# Altium schematic sheet STM32Bluetooth.SchDoc(STM32Bluetooth)
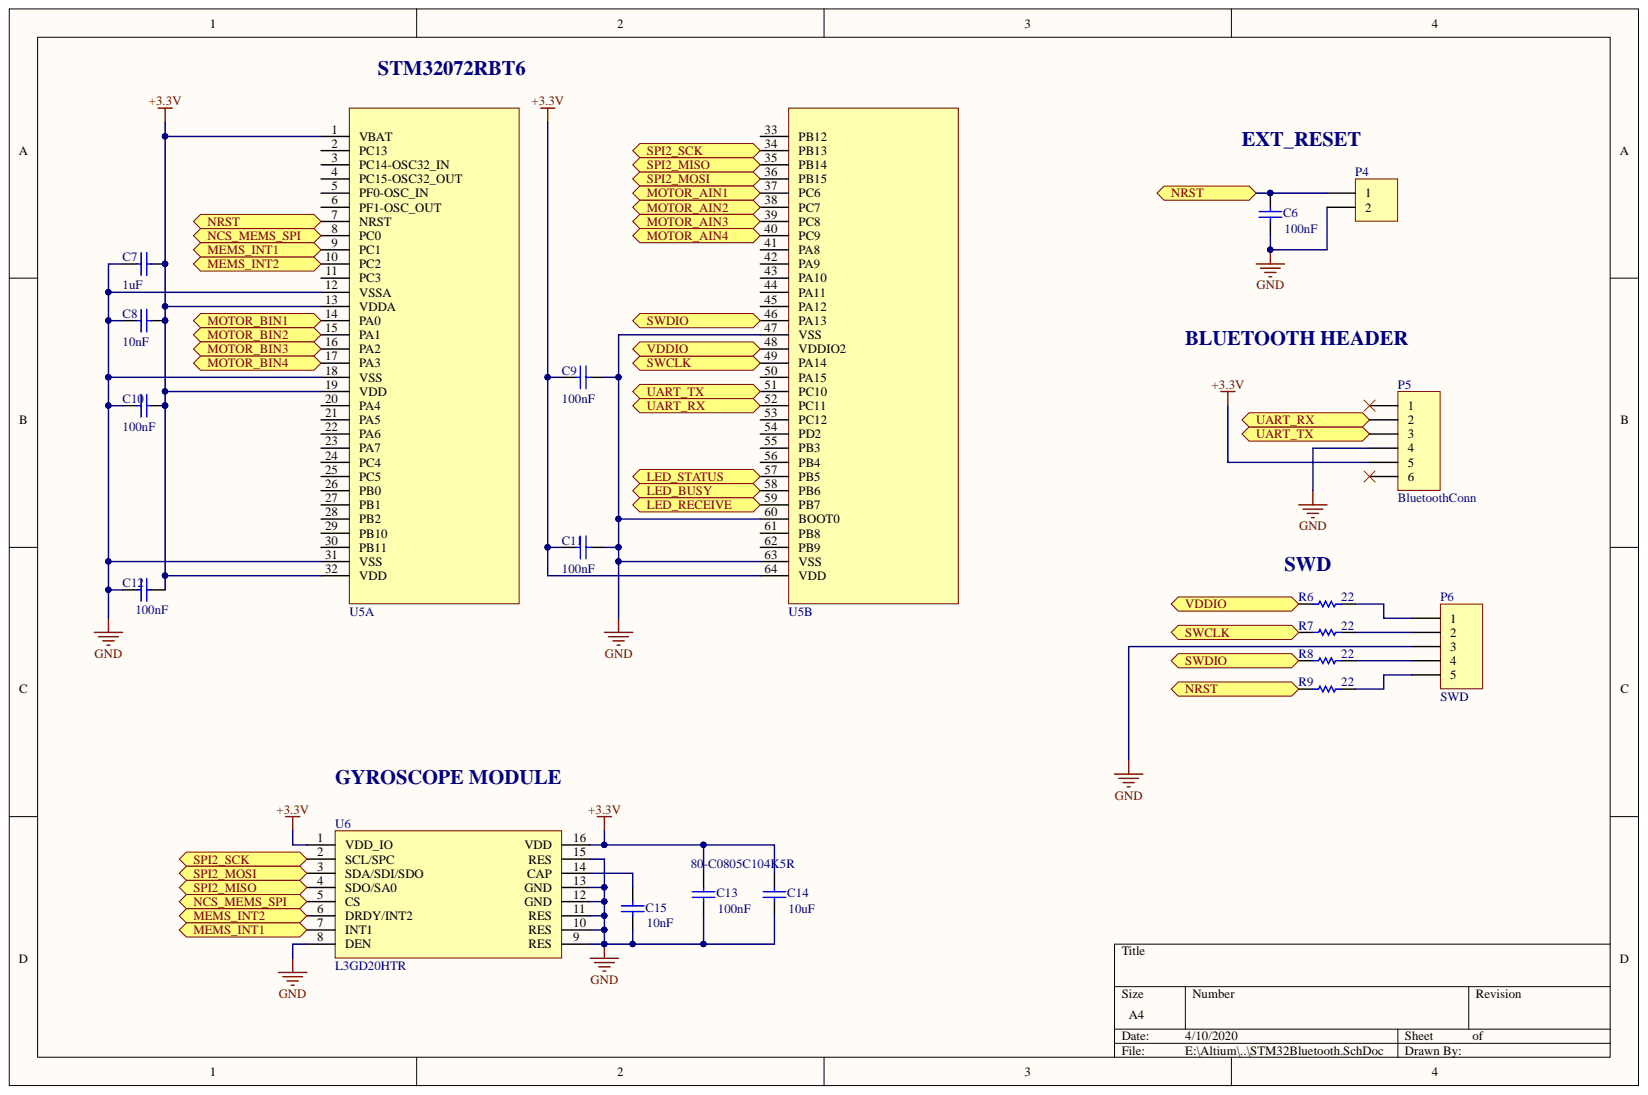
[diagram p0: STM32Bluetooth.SchDoc]

## Parts
C6  100nF  [C0805]
C7  1uF  [C0805]
C8  10nF  [C0805]
C9  100nF  [C0805]
C10  100nF  [C0805]
C11  100nF  [C0805]
C12  100nF  [C0805]
C13  100nF  Supplier Part Number 1: 80-C0805C104K5R  [C0805]
C14  10uF  [C0805]
C15  10nF  [C0805]
P4  Header 2  [HDR1X2]  (value not drawn)
P5  BluetoothConn  [HDR1X6]
P6  SWD  [HDR1X5]
R6  22  [6-0805_N]
R7  22  [6-0805_N]
R8  22  [6-0805_N]
R9  22  [6-0805_N]
U5  STM32F072RBT6  [STM32F072RBT6]  (value not drawn)  (parts A,B)
U6  L3GD20HTR  [L3GD20HTR]

## Ports
LED_BUSY, LED_RECEIVE, LED_STATUS, MEMS_INT1, MEMS_INT2, MOTOR_AIN1, MOTOR_AIN2, MOTOR_AIN3, MOTOR_AIN4, MOTOR_BIN1, MOTOR_BIN2, MOTOR_BIN3, MOTOR_BIN4, NCS_MEMS_SPI, NRST, SPI2_MISO, SPI2_MOSI, SPI2_SCK, SWCLK, SWDIO, UART_RX, UART_TX, VDDIO

## Nets
+3.3V: C7.1 C8.1 C9.2 C10.1 C11.2 C12.1 C13.2 C14.2 P5.5 U5.1(VBAT) U5.13(VDDA) U5.19(VDD) U5.32(VDD) U5.64(VDD) U6.1(VDD_IO) U6.16(VDD)
GND: C6.1 C7.2 C8.2 C9.1 C10.2 C11.1 C12.2 C13.1 C14.1 C15.1 P4.2 P5.4 P6.3 U5.12(VSSA) U5.18(VSS) U5.31(VSS) U5.47(VSS) U5.60(BOOT0) U5.63(VSS) U6.8(DEN) U6.9(RES) U6.10(RES) U6.11(RES) U6.12(GND) U6.13(GND) U6.15(RES)
LED_BUSY: U5.58(PB6) ; port LED_BUSY
LED_RECEIVE: U5.59(PB7) ; port LED_RECEIVE
LED_STATUS: U5.57(PB5) ; port LED_STATUS
MEMS_INT1: U5.9(PC1) U6.7(INT1) ; port MEMS_INT1
MEMS_INT2: U5.10(PC2) U6.6(DRDY/INT2) ; port MEMS_INT2
MOTOR_AIN1: U5.37(PC6) ; port MOTOR_AIN1
MOTOR_AIN2: U5.38(PC7) ; port MOTOR_AIN2
MOTOR_AIN3: U5.39(PC8) ; port MOTOR_AIN3
MOTOR_AIN4: U5.40(PC9) ; port MOTOR_AIN4
MOTOR_BIN1: U5.14(PA0) ; port MOTOR_BIN1
MOTOR_BIN2: U5.15(PA1) ; port MOTOR_BIN2
MOTOR_BIN3: U5.16(PA2) ; port MOTOR_BIN3
MOTOR_BIN4: U5.17(PA3) ; port MOTOR_BIN4
NCS_MEMS_SPI: U5.8(PC0) U6.5(CS) ; port NCS_MEMS_SPI
NRST: C6.2 P4.1 R9.2 U5.7(NRST) ; port NRST
SPI2_MISO: U5.35(PB14) U6.4(SDO/SA0) ; port SPI2_MISO
SPI2_MOSI: U5.36(PB15) U6.3(SDA/SDI/SDO) ; port SPI2_MOSI
SPI2_SCK: U5.34(PB13) U6.2(SCL/SPC) ; port SPI2_SCK
SWCLK: R7.2 U5.49(PA14) ; port SWCLK
SWDIO: R8.2 U5.46(PA13) ; port SWDIO
UART_RX: P5.2 U5.52(PC11) ; port UART_RX
UART_TX: P5.3 U5.51(PC10) ; port UART_TX
VDDIO: R6.2 U5.48(VDDIO2) ; port VDDIO
NetC15_2: C15.2 U6.14(CAP)
NetP6_1: P6.1 R6.1
NetP6_2: P6.2 R7.1
NetP6_4: P6.4 R8.1
NetP6_5: P6.5 R9.1

Not connected: P5 1 6; U5 2(PC13) 3(PC14-OSC32_IN) 4(PC15-OSC32_OUT) 5(PF0-OSC_IN) 6(PF1-OSC_OUT) 11(PC3) 20(PA4) 21(PA5) 22(PA6) 23(PA7) 24(PC4) 25(PC5) 26(PB0) 27(PB1) 28(PB2) 29(PB10) 30(PB11) 33(PB12) 41(PA8) 42(PA9) 43(PA10) 44(PA11) 45(PA12) 50(PA15) 53(PC12) 54(PD2) 55(PB3) 56(PB4) 61(PB8) 62(PB9)

## Library data of the parts
C6-C15 = Cap Semi: Capacitor (Semiconductor SIM Model); Simulation: CAP; Signal Integrity: Cap Semi; Published: 8-Jun-2000; PackageReference: C1206; PackageDescription: Chip Capacitor; Library Name: Miscellaneous Devices.IntLib; LatestRevisionNote: IPC-7351 Footprint Added.; LatestRevisionDate: 29-May-2009; Component Kind: Standard; Publisher: Altium Limited
  C6 C9 C10: Value: 100nF
  C7: Value: 1uF; Supplier Part Number 1: 80-C0805C105K4P; Supplier 1: Mouser
  C8: Value: 10nF; Supplier Part Number 1: 581-08052C103K; Supplier 1: Mouser
  C11: Value: 100nF; Supplier Part Number 1: 80-C0805C104K5RACLR; Supplier 1: Mouser
  C12: Value: 100nF; Supplier Part Number 1: 80-C0805C104K5R; Supplier 1: Mouser
  C13: Value: 100nF; Supplier 1: Mouser
  C14: Value: 10uF
  C15: Value: 10nF
P4 = Header 2: Header, 2-Pin; Signal Integrity: Connector; Library Name: Miscellaneous Connectors.IntLib; LatestRevisionNote: Re-released for DXP Platform.; LatestRevisionDate: 17-Jul-2002; Component Kind: Standard; Publisher: Altium Limited
P5 = Header 6: Header, 6-Pin; Signal Integrity: Connector; Library Name: Miscellaneous Connectors.IntLib; LatestRevisionNote: Re-released for DXP Platform.; LatestRevisionDate: 17-Jul-2002; Component Kind: Standard; Publisher: Altium Limited
P6 = Header 5: Header, 5-Pin; Signal Integrity: Connector; Library Name: Miscellaneous Connectors.IntLib; LatestRevisionNote: Re-released for DXP Platform.; LatestRevisionDate: 17-Jul-2002; Component Kind: Standard; Publisher: Altium Limited
R6-R9 = Res Semi: Semiconductor Resistor; Value: 22; Simulation: RES; Signal Integrity: Res Semi; Published: 8-Jun-2000; PackageReference: AXIAL-0.5; PackageDescription: Axial Device, Thru-Hole; 2 Leads; 0.5 in Pin Spacing; Library Name: Miscellaneous Devices.IntLib; LatestRevisionNote: Re-released for DXP Platform.; LatestRevisionDate: 17-Jul-2002; Component Kind: Standard; Publisher: Altium Limited
U5 = STM32F072RBT6: STMICROELECTRONICS - STM32F072RBT6 - 32 Bit Microcontroller, USB Line, ARM Cortex-M0, 48 MHz, 128 KB, 16 KB, 64, LQFP RoHS Compliant: Yes; RAM Size: 16KB; Peripherals: POR,PWM,WDT,DMA; Oscillator Type: Internal; Number of Timers/Counters: 9; Number of Programmable I/O: 51; Number of ADC Channels: 19; Min Supply Voltage: 2V; Memory Type: FLASH; Memory Size: 128KB; Max Supply Voltage: 3.6V; Max Power Dissipation: 455mW; Max Frequency: 48MHz; Interface: I2C,SPI,USB,CAN,HDMI,IrDA,UART,USART,LIN,I2S; Data Bus Width: 32b; Weight: 0.012088oz; Watchdog Timer: Yes; Supplier Part Number 1: 511-STM32F072RBT6; Supplier 1: Mouser; Stock 1: 1788; RoHS: Yes; REACH SVHC: No SVHC; Pricing 1: 1=3.93000, 10=3.34000, 100=2.90000, 250=2.75000, 500=2.47000, 1000=2.08000, 2500=1.98000, 5000=1.90000, 10000=1.79000 (USD); Packaging: Tray; Package Quantity: 960; Number of Pins: 64; Number of I/Os: 51; Mount: Surface Mount; Min Operating Temperature: -40°C; Max Operating Temperature: 85°C; Manufacturer Part Number: STM32F072RBT6; Manufacturer: STMicroelectronics; Lead Free: Lead Free; Frequency: 48MHz; Core Architecture: ARM; ComponentLink1URL: https://datasheet.ciiva.com/48492/dm00090510-48492217.pdf?src-supplier=Avnet; ComponentLink1Description: https://datasheet.ciiva.com/48492/dm00090510-48492217.pdf?src-supplier=Avnet; Component Kind: Standard; Case/Package: LQFP; Library Name: FSBPowerMotor.SchLib
U6 = L3GD20HTR: STMICROELECTRONICS - L3GD20HTR - MEMS-Gyroskop, Digital, X, Y, Z, ± 245°/s, ± 500°/s, ± 2000°/s, 2.2 V, 3.6 V, LGA; Sensor Type: 3 Axis; Resolution: 16b; Output Type: SPI,I2C,Digital; Operating Supply Current: 5mA; Min Supply Voltage (DC): 2.2V; Max Supply Voltage (DC): 3.6V; Interface: I2C,SPI; Width: 3mm; Supplier Part Number 1: 497-13931-1-ND; Supplier 1: Digi-Key; Stock 1: 11538; RoHS: Yes; Radiation Hardening: No; Pricing 1: 1=3.66000, 10=3.28300, 25=3.10360, 100=2.68980, 500=2.28980, 1000=1.93116 (USD); Packaging: Tape and Reel; Package Quantity: 1; Number of Pins: 16; Mount: Surface Mount; Min Supply Voltage: 2.2V; Min Operating Temperature: -40°C; Max Supply Voltage: 3.6V; Max Operating Temperature: 85°C; Manufacturer Part Number: L3GD20HTR; Manufacturer: STMicroelectronics; Length: 3mm; Lead Free: Lead Free; Height: 1mm; Contact Plating: Gold; ComponentLink1URL: https://datasheet.ciiva.com/29895/dm00060659-29895457.pdf?src-supplier=Avnet; ComponentLink1Description: https://datasheet.ciiva.com/29895/dm00060659-29895457.pdf?src-supplier=Avnet; Component Kind: Standard; Case/Package: LGA; Library Name: FSBPowerMotor.SchLib
